# Altium schematic sheet Gatekeeper_sheet6_environment_sensors_V2.1.SchDoc(Gatekeeper_sheet6_environment_sensors_V2.1)
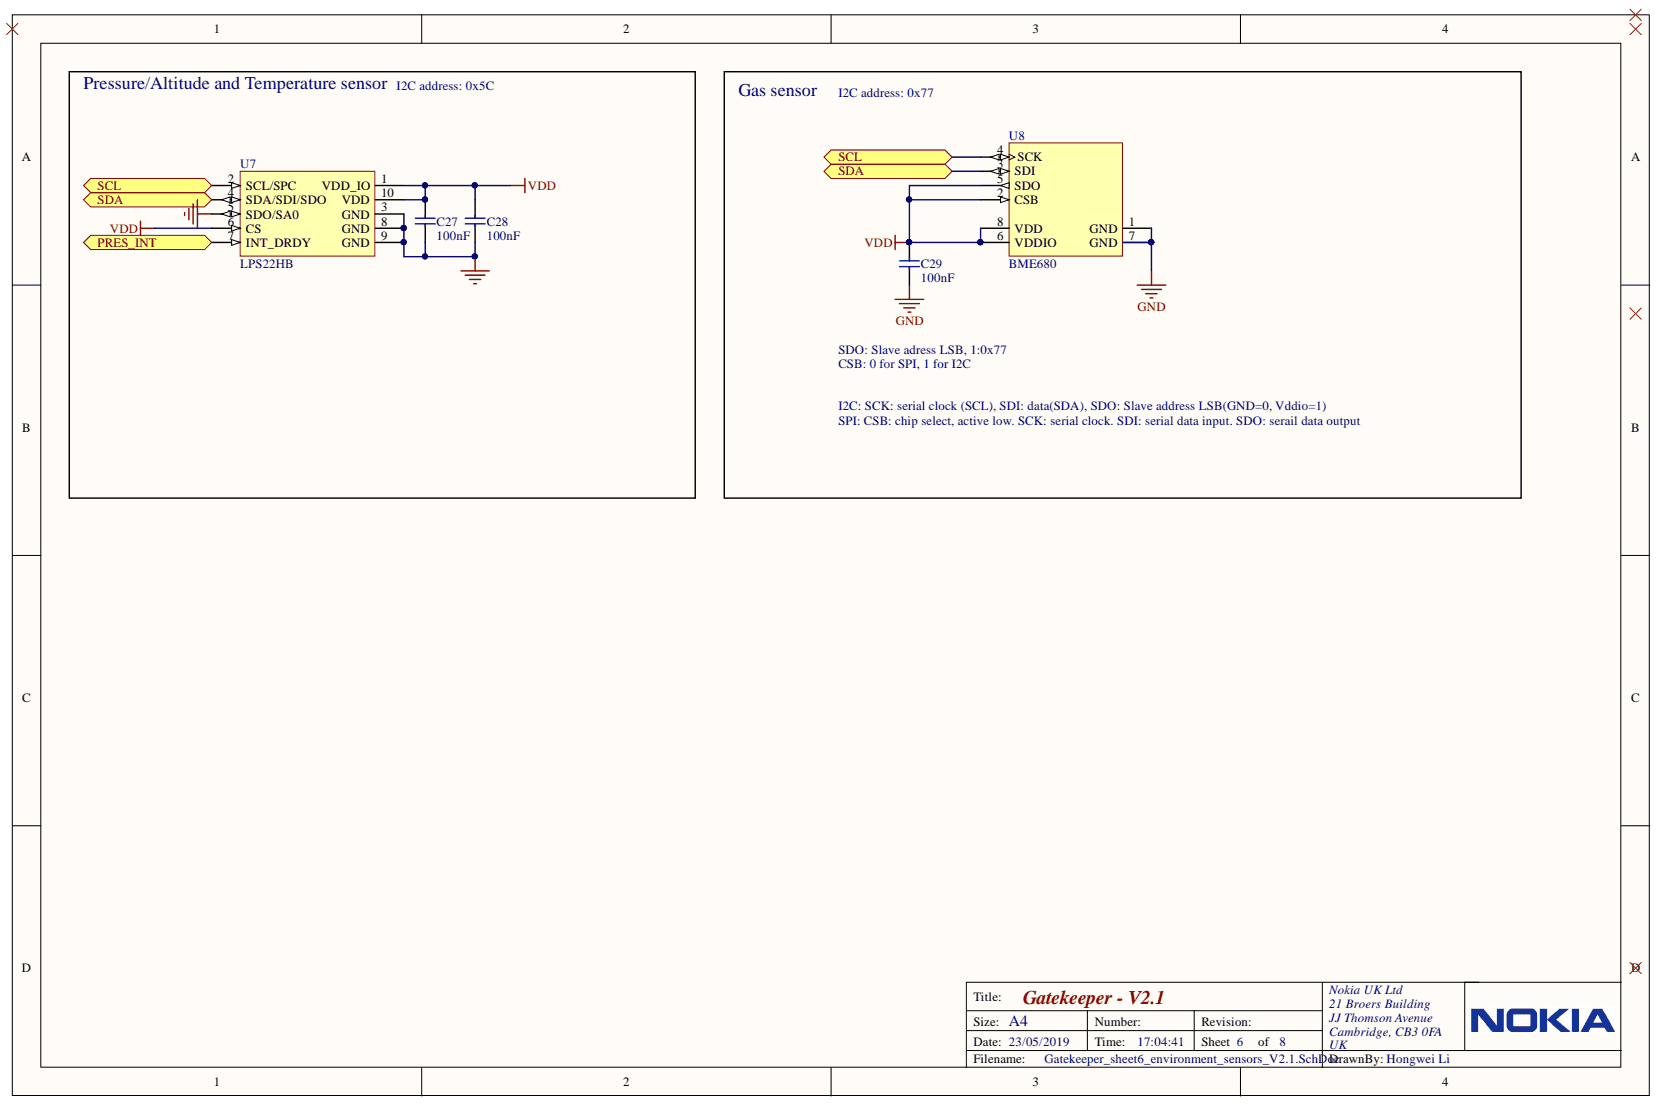
[diagram p0: Gatekeeper_sheet6_environment_sensors_V2.1.SchDoc]

## Parts
C27  100nF  [CAPC1005X04L]
C28  100nF  [CAPC1005X04L]
C29  100nF  [CAPC1005X04L]
U7  LPS22HB  [LGA50P200X200X80-10N]
U8  BME680  [BOSC-BME680-8_V]

## Ports
PRES_INT, SCL, SDA

## Nets
GND: C27.1 C28.1 C29.1 U7.3(GND) U7.5(SDO/SA0) U7.8(GND) U7.9(GND) U8.1(GND) U8.7(GND)
VDD: C27.2 C28.2 C29.2 U7.1(VDD_IO) U7.6(CS) U7.10(VDD) U8.2(CSB) U8.5(SDO) U8.6(VDDIO) U8.8(VDD)
PRES_INT: U7.7(INT_DRDY) ; port PRES_INT
SCL: U7.2(SCL/SPC) U8.4(SCK) ; port SCL
SDA: U7.4(SDA/SDI/SDO) U8.3(SDI) ; port SDA

## Library data of the parts
C27-C29 = (no symbol name): Capacitor, 0402, X7R, ±10%; Part Number: C0402; Manufacturer Part Number: 885012205037; Manufacturer: Wurth Electronics; 2nd source part number: 81-GCM155R71C104KA5D; Note: 16V; Value: 100nF; Supplier part number: 710-885012205037; Supplier: Mouser; Pin Count: 2; Footprint Doc: 0402; Datasheet: https://www.mouser.co.uk/datasheet/2/445/885012205037-554188.pdf; Component Kind: Standard; 2nd source Supplier: Mouser; Library Name: Gatekeeper.SCHLIB
U7 = LPS22HB: MEMS nano pressure sensor: 260-1260 hPa absolute digital output barometer; Manufacturer Part Number: LPS22HBTR; Manufacturer: STMicroelectronics; 2nd source part number: 497-16265-1-ND; Value: LPS22HB; Supplier part number: 511-LPS22HBTR; Supplier: Mouser; Pin Count: 10; Footprint Doc: HLGA-10L; Datasheet: http://www.st.com/content/ccc/resource/technical/document/datasheet/bf/c1/4f/23/61/17/44/8a/DM00140895.pdf/files/DM00140895.pdf/jcr:content/translations/en.DM00140895.pdf; Component Kind: Standard; 2nd source Supplier: DigiKey; Library Name: Gatekeeper.IntLib
U8 = BME680: Combined Humidity and Pressure Sensor, 1.71 to 3.6 V, -40 to 85 degC, 8-Pin SMD, RoHS, Tape and Reel; Manufacturer: Bosch Sensortec; 2nd source part number: 828-1077-1-ND; Supplier Part Number: 262-BME680; Supplier: Mouser; Manufacturer URL: http://www.bosch-sensortec.com; Manufacturer Part Number: BME680; Component Kind: Standard; 2nd source supplier: DigiKey; Library Name: Gatekeeper_V2.1.SchLib
